# Altium schematic sheet header.SchDoc(header)
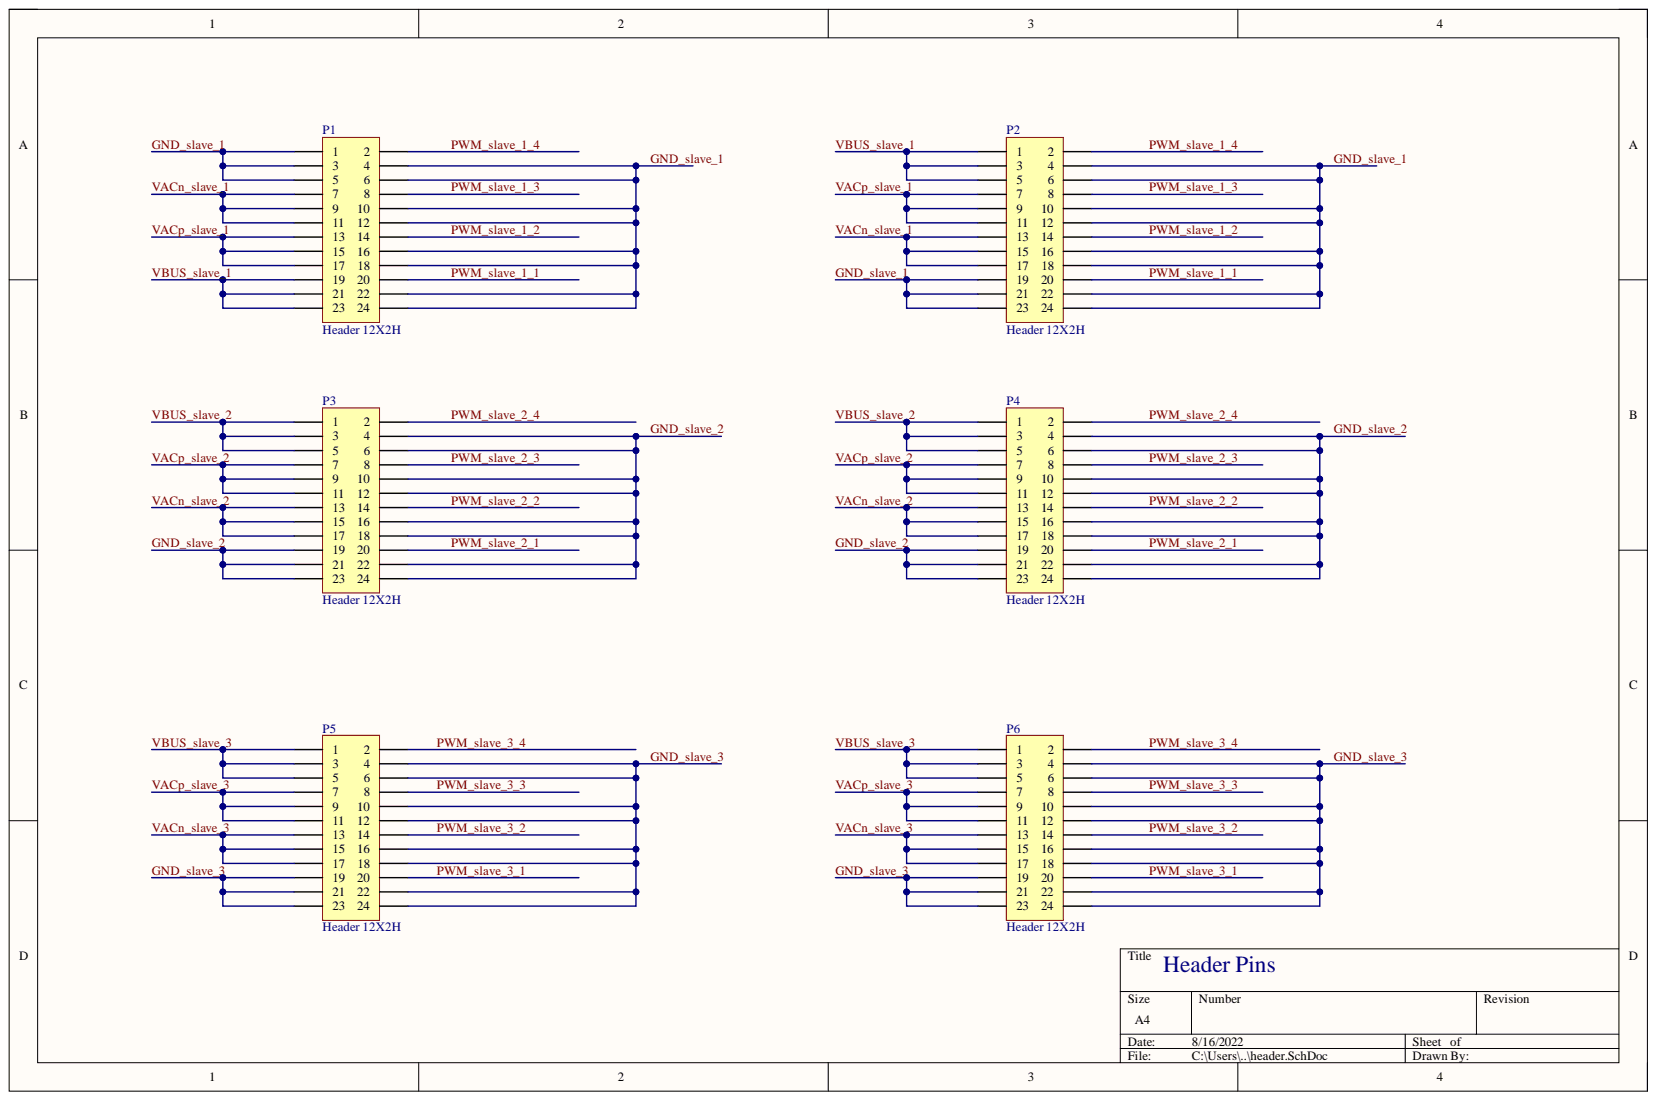
[diagram p0: header.SchDoc]

## Parts
P1  Header 12X2H  [HDR2X12H]
P2  Header 12X2H  [HDR2X12H]
P3  Header 12X2H  [HDR2X12H]
P4  Header 12X2H  [HDR2X12H]
P5  Header 12X2H  [HDR2X12H]
P6  Header 12X2H  [HDR2X12H]

## Nets
GND_slave_1: P1.1 P1.3 P1.4 P1.5 P1.6 P1.10 P1.12 P1.16 P1.18 P1.22 P1.24 P2.4 P2.6 P2.10 P2.12 P2.16 P2.18 P2.19 P2.21 P2.22 P2.23 P2.24
GND_slave_2: P3.4 P3.6 P3.10 P3.12 P3.16 P3.18 P3.19 P3.21 P3.22 P3.23 P3.24 P4.4 P4.6 P4.10 P4.12 P4.16 P4.18 P4.19 P4.21 P4.22 P4.23 P4.24
GND_slave_3: P5.4 P5.6 P5.10 P5.12 P5.16 P5.18 P5.19 P5.21 P5.22 P5.23 P5.24 P6.4 P6.6 P6.10 P6.12 P6.16 P6.18 P6.19 P6.21 P6.22 P6.23 P6.24
PWM_slave_1_1: P1.20 P2.20
PWM_slave_1_2: P1.14 P2.14
PWM_slave_1_3: P1.8 P2.8
PWM_slave_1_4: P1.2 P2.2
PWM_slave_2_1: P3.20 P4.20
PWM_slave_2_2: P3.14 P4.14
PWM_slave_2_3: P3.8 P4.8
PWM_slave_2_4: P3.2 P4.2
PWM_slave_3_1: P5.20 P6.20
PWM_slave_3_2: P5.14 P6.14
PWM_slave_3_3: P5.8 P6.8
PWM_slave_3_4: P5.2 P6.2
VACn_slave_1: P1.7 P1.9 P1.11 P2.13 P2.15 P2.17
VACn_slave_2: P3.13 P3.15 P3.17 P4.13 P4.15 P4.17
VACn_slave_3: P5.13 P5.15 P5.17 P6.13 P6.15 P6.17
VACp_slave_1: P1.13 P1.15 P1.17 P2.7 P2.9 P2.11
VACp_slave_2: P3.7 P3.9 P3.11 P4.7 P4.9 P4.11
VACp_slave_3: P5.7 P5.9 P5.11 P6.7 P6.9 P6.11
VBUS_slave_1: P1.19 P1.21 P1.23 P2.1 P2.3 P2.5
VBUS_slave_2: P3.1 P3.3 P3.5 P4.1 P4.3 P4.5
VBUS_slave_3: P5.1 P5.3 P5.5 P6.1 P6.3 P6.5

## Library data of the parts
P1-P6 = Header 12X2H: Header, 12-Pin, Dual row, Right Angle; Component Kind: Standard; LatestRevisionDate: 17-Jul-2002; LatestRevisionNote: Re-released for DXP Platform.; Library Name: Miscellaneous Connectors.IntLib; Signal Integrity: Connector; Publisher: Altium Limited
